# Altium schematic sheet [04]-CPU-JTAG,POWER.SchDoc
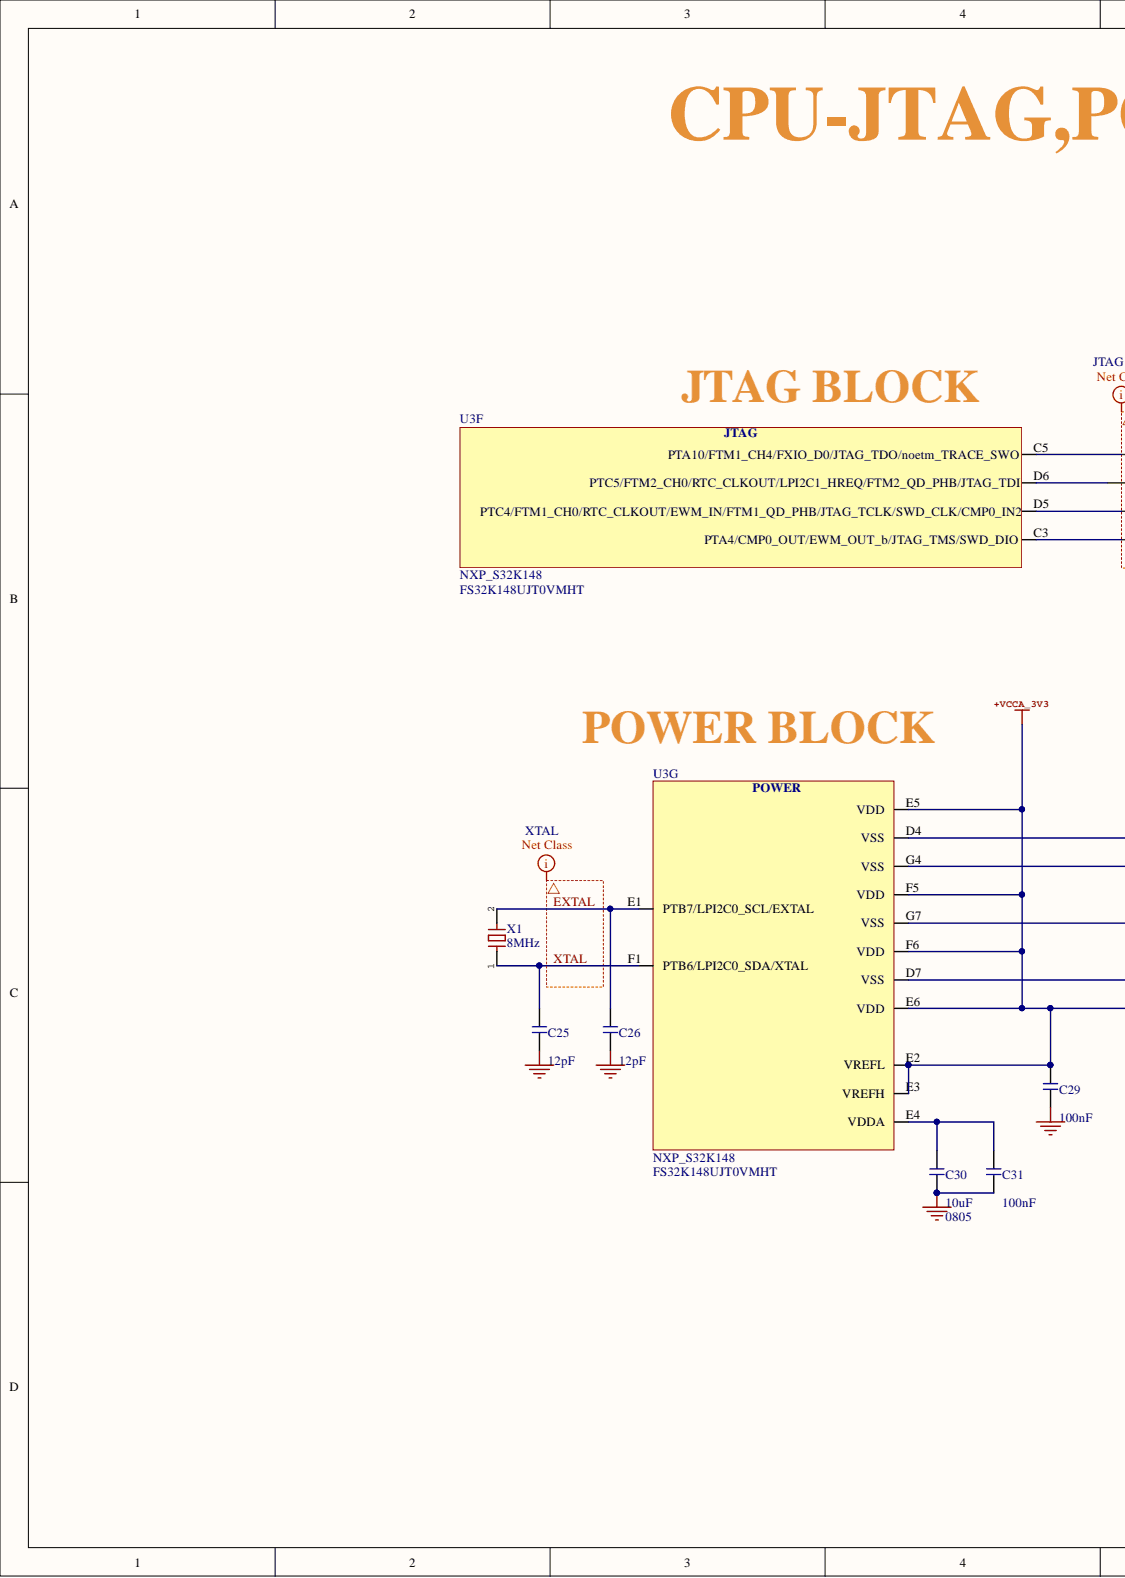
[diagram p0: [04]-CPU-JTAG,POWER.SchDoc, left]
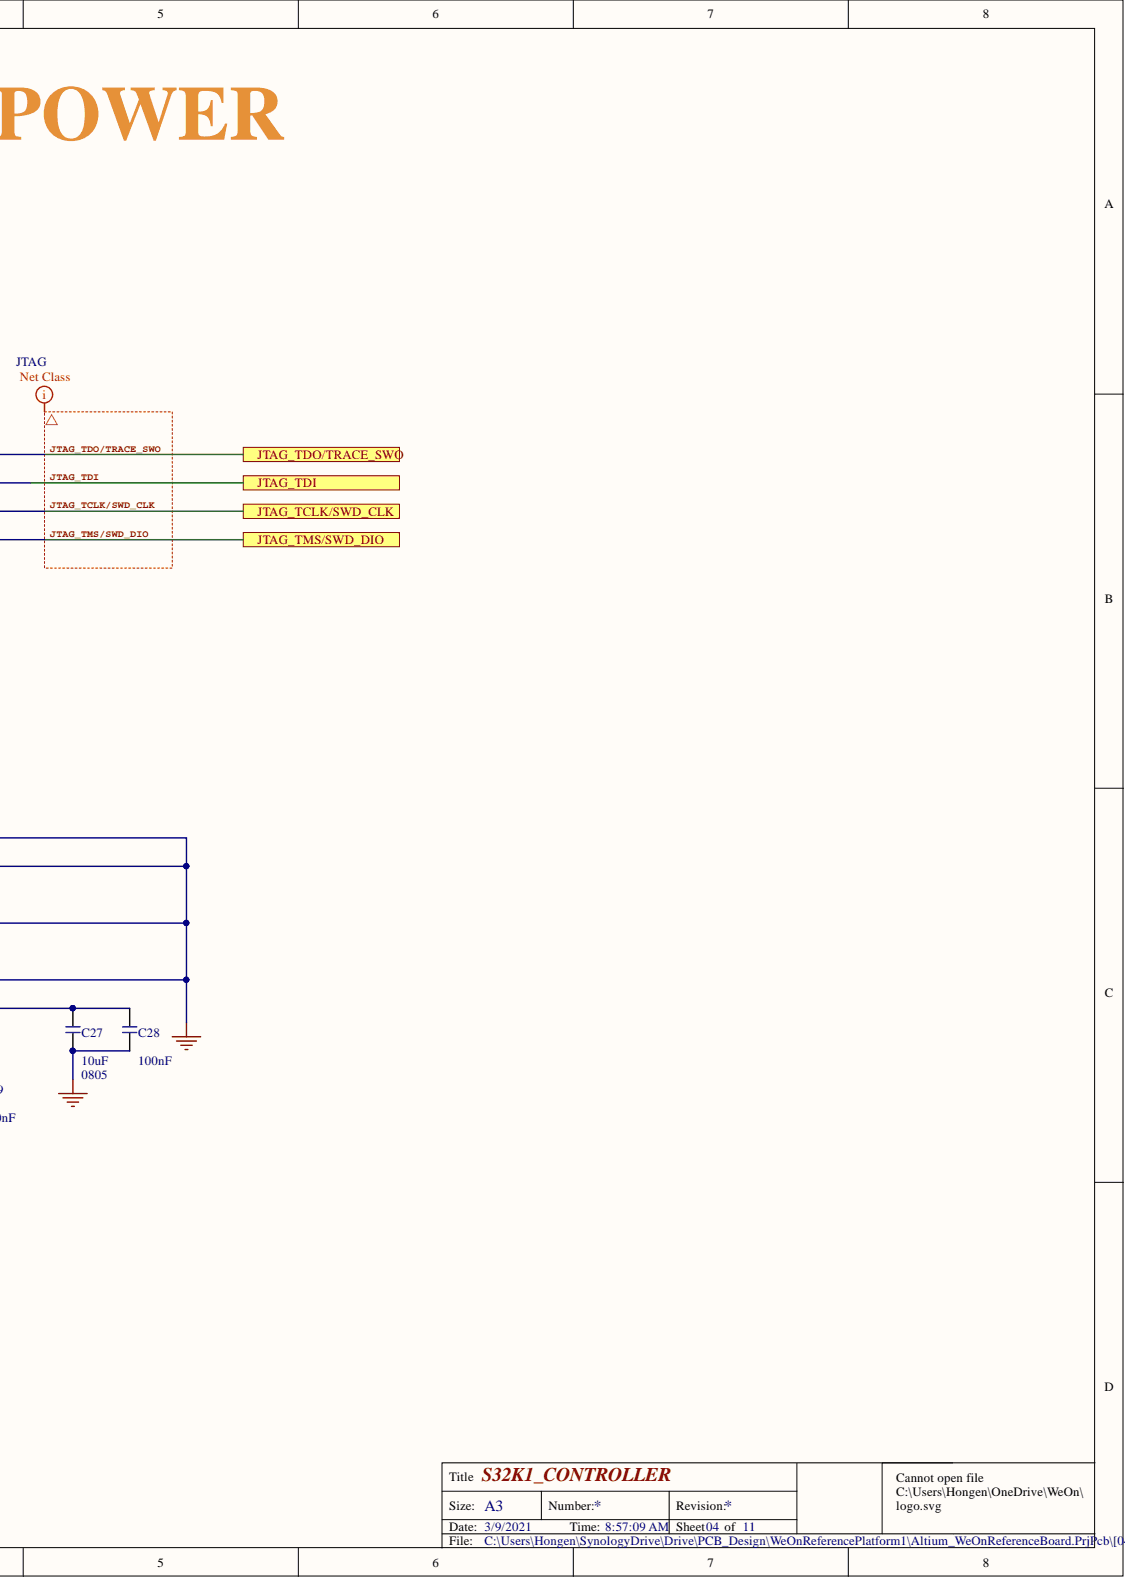
[diagram p1: [04]-CPU-JTAG,POWER.SchDoc, right]

## Parts
C25  value 12pF  [CAP0402]  (hidden comment: GCQ1555C1H120GB01D)
C26  value 12pF  [CAP0402]  (hidden comment: GCQ1555C1H120GB01D)
C27  Capacitance: 10uF  Case/Package: 0805  [CAP0805]  (hidden comment: CGA4J1X5R1C106K125AC)
C28  Capacitance: 100nF  [CAP0402]  (hidden comment: GCM155R71C104KA55D)
C29  Capacitance: 100nF  [CAP0402]  (hidden comment: GCM155R71C104KA55D)
C30  Capacitance: 10uF  Case/Package: 0805  [CAP0805]  (hidden comment: CGA4J1X5R1C106K125AC)
C31  Capacitance: 100nF  [CAP0402]  (hidden comment: GCM155R71C104KA55D)
U3  NXP_S32K148  Manufacturer Part Number: FS32K148UJT0VMHT  [WEONREFERENCEPLATFORM_100MAPBGA]  (parts F,G)
X1  value 8MHz  [CRYSTAL_NX3225]  (hidden comment: NX3225GD-8MHZ-EXS00A-CG04874)

## Ports
JTAG_TCLK/SWD_CLK, JTAG_TDI, JTAG_TDO/TRACE_SWO, JTAG_TMS/SWD_DIO

## Nets
GND: C25.2 C26.2 C27.2 C28.2 C29.2 C30.2 C31.2 U3.D4(VSS) U3.D7(VSS) U3.G4(VSS) U3.G7(VSS)
+VCCA_3V3: C27.1 C28.1 C29.1 U3.E2(VREFL) U3.E3(VREFH) U3.E5(VDD) U3.E6(VDD) U3.F5(VDD) U3.F6(VDD)
EXTAL: C26.1 U3.E1(PTB7/LPI2C0_SCL/EXTAL) X1.2
JTAG_TCLK/SWD_CLK: U3.D5(PTC4/FTM1_CH0/RTC_CLKOUT/EWM_IN/FTM1_QD_PHB/JTAG_TCLK/SWD_CLK/CMP0_IN2) ; port JTAG_TCLK/SWD_CLK
JTAG_TDI: U3.D6(PTC5/FTM2_CH0/RTC_CLKOUT/LPI2C1_HREQ/FTM2_QD_PHB/JTAG_TDI) ; port JTAG_TDI
JTAG_TDO/TRACE_SWO: U3.C5(PTA10/FTM1_CH4/FXIO_D0/JTAG_TDO/noetm_TRACE_SWO) ; port JTAG_TDO/TRACE_SWO
JTAG_TMS/SWD_DIO: U3.C3(PTA4/CMP0_OUT/EWM_OUT_b/JTAG_TMS/SWD_DIO) ; port JTAG_TMS/SWD_DIO
XTAL: C25.1 U3.F1(PTB6/LPI2C0_SDA/XTAL) X1.1
NetC30_1: C30.1 C31.1 U3.E4(VDDA)
N00107:
N00108:

## Library data of the parts
C25 C26 = GCQ1555C1H120GB01D: Cap Ceramic 12pF 50V C0G 2% Pad SMD 0402 125C Automotive T/R; Manufacturer: Murata; Component Kind: Standard; Manufacturer Part Number: GCQ1555C1H120GB01D; Pricing 1: 1 = 0.41000, 10 = 0.28100, 50 = 0.28100, 100 = 0.16900, 1000 = 0.10700, 10000 = 0.07600 (USD); Stock 1: 18590; Supplier 1: Mouser; Supplier Part Number 1: 81-GCQ1555C1H120GB1D
  C26: value: 12pF
C27 C30 = CGA4J1X5R1C106K125AC: CGA Series 0805 10 uF 16 V ±10 % Tolerance X5R SMT Multilayer Ceramic Capacitor; Manufacturer: TDK; Tolerance: 10%; Voltage Rating: 16V; Case Code (Imperial): 0805; Case Code (Metric): 2012; Component Kind: Standard; ComponentLink1Description: http://product.tdk.com/capacitor/mlcc/detailed_information.php?lang=en&amp;ref=jp&amp;part_no=CGA4J1X5R1C106K125AC&src-supplier=Mouser; ComponentLink1URL: http://product.tdk.com/capacitor/mlcc/detailed_information.php?lang=en&amp;ref=jp&amp;part_no=CGA4J1X5R1C106K125AC&src-supplier=Mouser; ComponentLink2Description: http://www.farnell.com/datasheets/1807242.pdf?src-supplier=Element14; ComponentLink2URL: http://www.farnell.com/datasheets/1807242.pdf?src-supplier=Element14; ComponentLink3Description: http://datasheet.octopart.com/CGA4J1X5R1C106K125AC-TDK-datasheet-12956201.pdf?src-supplier=Newark; ComponentLink3URL: http://datasheet.octopart.com/CGA4J1X5R1C106K125AC-TDK-datasheet-12956201.pdf?src-supplier=Newark; ComponentLink4Description: http://datasheet.octopart.com/CGA4J1X5R1C106K125AC-TDK-datasheet-13376850.pdf?src-supplier=Newark; ComponentLink4URL: http://datasheet.octopart.com/CGA4J1X5R1C106K125AC-TDK-datasheet-13376850.pdf?src-supplier=Newark; ComponentLink5Description: http://datasheet.octopart.com/CGA4J1X5R1C106K125AC-TDK-datasheet-33009218.pdf?src-supplier=Newark; ComponentLink5URL: http://datasheet.octopart.com/CGA4J1X5R1C106K125AC-TDK-datasheet-33009218.pdf?src-supplier=Newark; ComponentLink6Description: http://datasheet.octopart.com/CGA4J1X5R1C106K125AC-TDK-datasheet-32363556.pdf?src-supplier=Future+Electronics; ComponentLink6URL: http://datasheet.octopart.com/CGA4J1X5R1C106K125AC-TDK-datasheet-32363556.pdf?src-supplier=Future+Electronics; ComponentLink7Description: http://datasheet.octopart.com/CGA4J1X5R1C106K125AC-TDK-datasheet-26765558.pdf?src-supplier=Future+Electronics; ComponentLink7URL: http://datasheet.octopart.com/CGA4J1X5R1C106K125AC-TDK-datasheet-26765558.pdf?src-supplier=Future+Electronics; ComponentLink8Description: http://datasheet.octopart.com/CGA4J1X5R1C106K125AC-TDK-datasheet-43366117.pdf?src-supplier=Newark; ComponentLink8URL: http://datasheet.octopart.com/CGA4J1X5R1C106K125AC-TDK-datasheet-43366117.pdf?src-supplier=Newark; Composition: Ceramic; Depth: 1.25mm; Dielectric: X5R; Height: 1.25mm; Lead Free: Lead Free; Length: 2mm; Manufacturer Part Number: CGA4J1X5R1C106K125AC; Max Operating Temperature: 85°C; Min Operating Temperature: -55°C; Mount: Surface Mount; Package Quantity: 1; Packaging: Tape and Reel; Pricing 1: 1 = 0.60000, 10 = 0.41400, 100 = 0.24840, 500 = 0.18630, 1000 = 0.15732 (USD); Ratings: AEC-Q200; REACH SVHC: No SVHC; Stock 1: 128127; Supplier 1: Digi-Key; Supplier Part Number 1: 445-7883-1-ND; Termination: SMD/SMT; Thickness: 0.049inch; Voltage Rating (DC): 16V; Weight: 0.000194oz; Width: 0.049inch
C28 C29 C31 = GCM155R71C104KA55D: Multilayer Ceramic Capacitors (MLCC) - SMD/SMT 0402 0.1uF 16volts X7R 10%; Manufacturer: Murata; Voltage: 16V; Case/Package: 0402; Case Code (Imperial): 0402; Case Code (Metric): 1005; Component Kind: Standard; ComponentLink1Description: http://psearch.en.murata.com/capacitor/product/GCM155R71C104KA55%23.pdf?src-supplier=Avnet; ComponentLink1URL: http://psearch.en.murata.com/capacitor/product/GCM155R71C104KA55%23.pdf?src-supplier=Avnet; ComponentLink2Description: http://search.murata.co.jp/Ceramy/image/img/A01X/partnumbering_e_02.pdf?src-supplier=Digi-Key; ComponentLink2URL: http://search.murata.co.jp/Ceramy/image/img/A01X/partnumbering_e_02.pdf?src-supplier=Digi-Key; ComponentLink3Description: http://uk.rs-online.com/webdocs/1423/0900766b81423e06.pdf?src-supplier=RSComponents; ComponentLink3URL: http://uk.rs-online.com/webdocs/1423/0900766b81423e06.pdf?src-supplier=RSComponents; ComponentLink4Description: https://www.verical.com/server-webapp/html/getDatasheet?partID=220774&src-supplier=Verical; ComponentLink4URL: https://www.verical.com/server-webapp/html/getDatasheet?partID=220774&src-supplier=Verical; ComponentLink5Description: http://datasheet.octopart.com/GCM155R71C104KA55D-Murata-datasheet-11777063.pdf?src-supplier=_legacy+Avnet; ComponentLink5URL: http://datasheet.octopart.com/GCM155R71C104KA55D-Murata-datasheet-11777063.pdf?src-supplier=_legacy+Avnet; ComponentLink6Description: http://datasheet.octopart.com/GCM155R71C104KA55D-Murata-datasheet-14404342.pdf?src-supplier=Future+Electronics; ComponentLink6URL: http://datasheet.octopart.com/GCM155R71C104KA55D-Murata-datasheet-14404342.pdf?src-supplier=Future+Electronics; ComponentLink7Description: http://datasheet.octopart.com/GCM155R71C104KA55D-Murata-datasheet-12515163.pdf?src-supplier=Farnell; ComponentLink7URL: http://datasheet.octopart.com/GCM155R71C104KA55D-Murata-datasheet-12515163.pdf?src-supplier=Farnell; ComponentLink8Description: http://datasheet.octopart.com/GCM155R71C104KA55D-Murata-datasheet-44104237.pdf?src-supplier=Future+Electronics; ComponentLink8URL: http://datasheet.octopart.com/GCM155R71C104KA55D-Murata-datasheet-44104237.pdf?src-supplier=Future+Electronics; ComponentLink9Description: http://datasheet.octopart.com/GCM155R71C104KA55D-Murata-datasheet-62117434.pdf?src-supplier=TME; ComponentLink9URL: http://datasheet.octopart.com/GCM155R71C104KA55D-Murata-datasheet-62117434.pdf?src-supplier=TME; Depth: 0.5mm; Dielectric: X7R; Height: 0.5mm; Lead Free: Lead Free; Length: 1mm; Manufacturer Part Number: GCM155R71C104KA55D; Max Operating Temperature: 125°C; Min Operating Temperature: -55°C; Mount: Surface Mount; Package Quantity: 1; Packaging: Tape and Reel; Pricing 1: 10000 = 0.01910, 1 = 0.05560, 10 = 0.04750, 25 = 0.03370, 100 = 0.02190, 250 = 0.02080 (USD); Radiation Hardening: No; Ratings: AEC-Q200; REACH SVHC: No SVHC; Stock 1: 10660000; Supplier 1: Arrow; Supplier Part Number 1: GCM155R71C104KA55D; Termination: SMD/SMT; Thickness: 0.022inch; Tolerance: 10%; Voltage Rating: 16V; Voltage Rating (DC): 16V; Width: 0.02inch
U3 = NXP_S32K148: Manufacturer: NXP Semiconductors; Component Kind: Standard; Library Name: WeOnReferenceBoard.SCHLIB; Pricing 1: 1 = 16.32000, 10 = 14.99600, 25 = 14.37440, 100 = 12.66510, 880 = 11.26650 (USD); Stock 1: 855; Supplier 1: Digikey; Supplier Part Number 1: 568-13929-ND
X1 = NX3225GD-8MHZ-EXS00A-CG04874: Crystal 8MHZ 8PF SMD; Manufacturer: NDK; Component Kind: Standard; Manufacturer Part Number: NX3225GD-8MHZ-EXS00A-CG04874; Pricing 1: 1 = 0.77000, 10 = 0.68300, 50 = 0.63860, 100 = 0.56430, 500 = 0.53460, 1000 = 0.44550 (USD); Stock 1: 5784; Supplier 1: Digi-Key; Supplier Part Number 1: 644-1391-1-ND
